# Altium schematic sheet Audio_Input_Mic_In.SchDoc
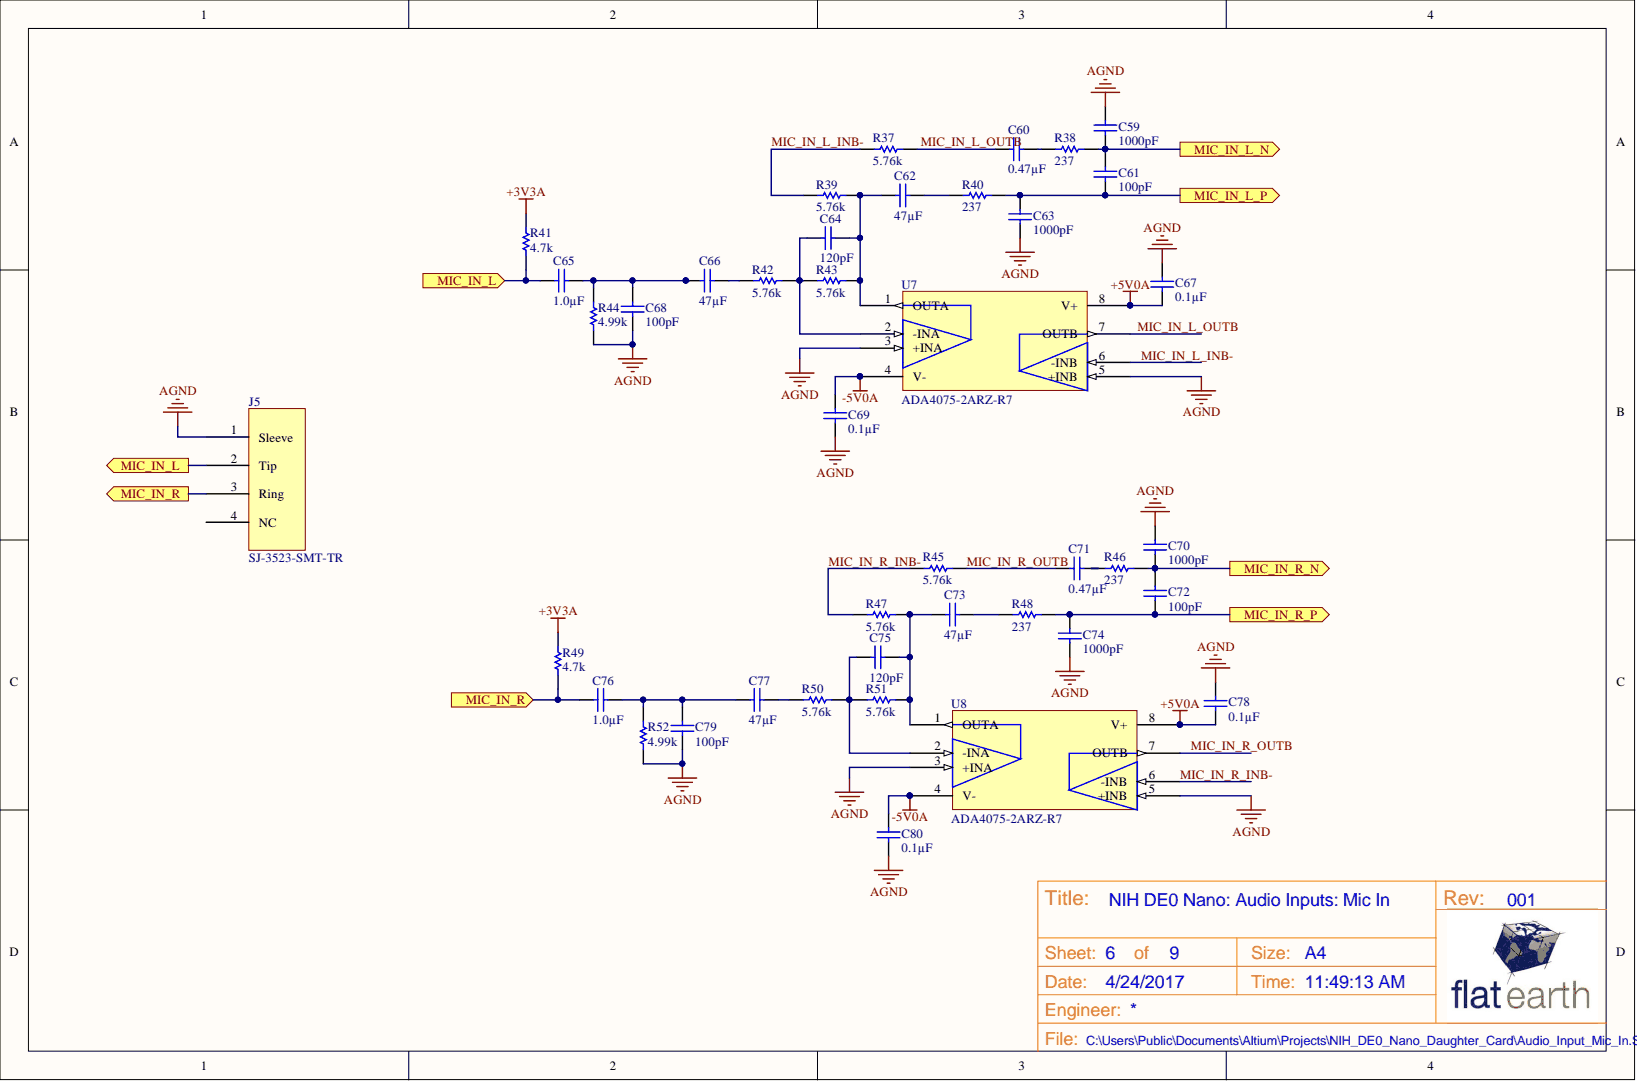
[diagram p0: Audio_Input_Mic_In.SchDoc]

## Parts
C59  Capacitance: 1000pF  [3-0603_L]  (hidden comment: CL10B102KB8NNNC)
C60  Capacitance: 0.47µF  [3-0603_L]  (hidden comment: C1608X7R1H474K080AC)
C61  Capacitance: 100pF  [3-0603_L]  (hidden comment: C1608C0G2A101J)
C62  Capacitance: 47µF  [C1210X25_L]  (hidden comment: GRM32EC81C476KE15L)
C63  Capacitance: 1000pF  [3-0603_L]  (hidden comment: CL10B102KB8NNNC)
C64  Capacitance: 120pF  [3-0603_L]  (hidden comment: CL10C121JB8NCNC)
C65  Capacitance: 1.0µF  [3-0603_L]  (hidden comment: C1608X7R1C105K)
C66  Capacitance: 47µF  [C1210X25_L]  (hidden comment: GRM32EC81C476KE15L)
C67  Capacitance: 0.1µF  [3-0603_L]  (hidden comment: C1608X7R1H104K)
C68  Capacitance: 100pF  [3-0603_L]  (hidden comment: C1608C0G2A101J)
C69  Capacitance: 0.1µF  [3-0603_L]  (hidden comment: C1608X7R1H104K)
C70  Capacitance: 1000pF  [3-0603_L]  (hidden comment: CL10B102KB8NNNC)
C71  Capacitance: 0.47µF  [3-0603_L]  (hidden comment: C1608X7R1H474K080AC)
C72  Capacitance: 100pF  [3-0603_L]  (hidden comment: C1608C0G2A101J)
C73  Capacitance: 47µF  [C1210X25_L]  (hidden comment: GRM32EC81C476KE15L)
C74  Capacitance: 1000pF  [3-0603_L]  (hidden comment: CL10B102KB8NNNC)
C75  Capacitance: 120pF  [3-0603_L]  (hidden comment: CL10C121JB8NCNC)
C76  Capacitance: 1.0µF  [3-0603_L]  (hidden comment: C1608X7R1C105K)
C77  Capacitance: 47µF  [C1210X25_L]  (hidden comment: GRM32EC81C476KE15L)
C78  Capacitance: 0.1µF  [3-0603_L]  (hidden comment: C1608X7R1H104K)
C79  Capacitance: 100pF  [3-0603_L]  (hidden comment: C1608C0G2A101J)
C80  Capacitance: 0.1µF  [3-0603_L]  (hidden comment: C1608X7R1H104K)
J5  SJ-3523-SMT-TR  [SJ-3523-SMT-TR]
R37  Resistance (Ohms): 5.76k  [3-0603_R_L]  (hidden comment: ERJ-3EKF5761V)
R38  ERJ-3EKF2370V  [3-0603_R_L]  (value not drawn)
R39  Resistance (Ohms): 5.76k  [3-0603_R_L]  (hidden comment: ERJ-3EKF5761V)
R40  ERJ-3EKF2370V  [3-0603_R_L]  (value not drawn)
R41  Resistance (Ohms): 4.7k  [3-0603_R_L]  (hidden comment: ERJ-3EKF4701V)
R42  Resistance (Ohms): 5.76k  [3-0603_R_L]  (hidden comment: ERJ-3EKF5761V)
R43  Resistance (Ohms): 5.76k  [3-0603_R_L]  (hidden comment: ERJ-3EKF5761V)
R44  Resistance (Ohms): 4.99k  [3-0603_R_L]  (hidden comment: ERJ-3EKF4991V)
R45  Resistance (Ohms): 5.76k  [3-0603_R_L]  (hidden comment: ERJ-3EKF5761V)
R46  ERJ-3EKF2370V  [3-0603_R_L]  (value not drawn)
R47  Resistance (Ohms): 5.76k  [3-0603_R_L]  (hidden comment: ERJ-3EKF5761V)
R48  ERJ-3EKF2370V  [3-0603_R_L]  (value not drawn)
R49  Resistance (Ohms): 4.7k  [3-0603_R_L]  (hidden comment: ERJ-3EKF4701V)
R50  Resistance (Ohms): 5.76k  [3-0603_R_L]  (hidden comment: ERJ-3EKF5761V)
R51  Resistance (Ohms): 5.76k  [3-0603_R_L]  (hidden comment: ERJ-3EKF5761V)
R52  Resistance (Ohms): 4.99k  [3-0603_R_L]  (hidden comment: ERJ-3EKF4991V)
U7  ADA4075-2ARZ-R7  [SOIC127P600X175-8L]
U8  ADA4075-2ARZ-R7  [SOIC127P600X175-8L]

## Ports
MIC_IN_L, MIC_IN_L_N, MIC_IN_L_P, MIC_IN_R, MIC_IN_R_N, MIC_IN_R_P

## Nets
+3V3A: R41.2 R49.2
+5V0A: C67.1 C78.1 U7.8(V+) U8.8(V+)
-5V0A: C69.1 C80.1 U7.4(V-) U8.4(V-)
AGND: C59.1 C63.1 C67.2 C68.2 C69.2 C70.1 C74.1 C78.2 C79.2 C80.2 J5.1(Sleeve) R44.1 R52.1 U7.3(+INA) U7.5(+INB) U8.3(+INA) U8.5(+INB)
MIC_IN_L: C65.1 J5.2(Tip) R41.1 ; port MIC_IN_L
MIC_IN_L_INB-: R37.1 R39.1 U7.6(-INB)
MIC_IN_L_N: C59.2 C61.2 R38.2 ; port MIC_IN_L_N
MIC_IN_L_OUTB: C60.1 R37.2 U7.7(OUTB)
MIC_IN_L_P: C61.1 C63.2 R40.2 ; port MIC_IN_L_P
MIC_IN_R: C76.1 J5.3(Ring) R49.1 ; port MIC_IN_R
MIC_IN_R_INB-: R45.1 R47.1 U8.6(-INB)
MIC_IN_R_N: C70.2 C72.2 R46.2 ; port MIC_IN_R_N
MIC_IN_R_OUTB: C71.1 R45.2 U8.7(OUTB)
MIC_IN_R_P: C72.1 C74.2 R48.2 ; port MIC_IN_R_P
NetC60_2: C60.2 R38.1
NetC62_1: C62.1 C64.2 R39.2 R43.2 U7.1(OUTA)
NetC62_2: C62.2 R40.1
NetC64_1: C64.1 R42.2 R43.1 U7.2(-INA)
NetC65_2: C65.2 C66.1 C68.1 R44.2
NetC66_2: C66.2 R42.1
NetC71_2: C71.2 R46.1
NetC73_1: C73.1 C75.2 R47.2 R51.2 U8.1(OUTA)
NetC73_2: C73.2 R48.1
NetC75_1: C75.1 R50.2 R51.1 U8.2(-INA)
NetC76_2: C76.2 C77.1 C79.1 R52.2
NetC77_2: C77.2 R50.1

Not connected: J5 4(NC)

## Library data of the parts
C59 C63 C70 C74 = CL10B102KB8NNNC: 1000pF 50V Ceramic Capacitor X7R 0603 (1608 Metric) 0.063" L x 0.031" W (1.60mm x 0.80mm); Manufacturer: Samsung Electro-Mechanics America, Inc.; Tolerance: ±10%; Applications: General Purpose; Category: Capacitors; Component Kind: Standard; ComponentLink1Description: http://www.samsungsem.com/kr/support/product-search/mlcc/__icsFiles/afieldfile/2015/06/15/CL10B102KB8NNNC.pdf; ComponentLink1URL: http://www.samsungsem.com/kr/support/product-search/mlcc/__icsFiles/afieldfile/2015/06/15/CL10B102KB8NNNC.pdf; Features: -; Height - Seated (Max): -; Lead Spacing: -; Lead Style: -; Library Name: Schematic_Components.SchLib; Manufacturer Part Number: CL10B102KB8NNNC; Mounting Type: Surface Mount, MLCC; Operating Temperature: -55°C ~ 125°C; Package / Case: 0603 (1608 Metric); Packaging: Cut Tape (CT); Part Status: Active; Pricing 1: 1=0.1, 10=0.017, 25=0.012, 50=0.0092, 100=0.0077, 500=0.0054, 1000=0.0042 (USD); Ratings: -; RoHS: RoHS Compliant; Size / Dimension: 0.063" L x 0.031" W (1.60mm x 0.80mm); Stock 1: 294007; Supplier 1: Digi-Key; Supplier Part Number 1: 1276-1018-1-ND; Temperature Coefficient: X7R; Thickness (Max): 0.035" (0.90mm); Voltage - Rated: 50V
C60 C71 = C1608X7R1H474K080AC: CAP CER 0.47UF 50V 10% X7R 0603; Manufacturer: TDK Corporation; Applications: General Purpose; Category: Capacitors; Component Kind: Standard; ComponentLink1Description: http://product.tdk.com/capacitor/mlcc/en/documents/mlcc_commercial_general_en.pdf; ComponentLink1URL: http://product.tdk.com/capacitor/mlcc/en/documents/mlcc_commercial_general_en.pdf; Features: -; Height - Seated (Max): -; Lead Spacing: -; Lead Style: -; Library Name: Schematic_Components.SchLib; Manufacturer Part Number: C1608X7R1H474K080AC; Mounting Type: Surface Mount, MLCC; Operating Temperature: -55°C ~ 125°C; Package / Case: 0603 (1608 Metric); Packaging: Cut Tape (CT); Pricing 1: 1=0.24, 10=0.2, 100=0.1, 250=0.075, 500=0.06626, 1000=0.05875 (USD); Ratings: -; RoHS: RoHS Compliant; Size / Dimension: 0.063" L x 0.031" W (1.60mm x 0.80mm); Stock 1: 0; Supplier 1: Digi-Key; Supplier Part Number 1: 445-5952-1-ND; Temperature Coefficient: X7R; Thickness (Max): 0.037" (0.95mm); Tolerance: ±10%; Voltage - Rated: 50V
C61 C68 C72 C79 = C1608C0G2A101J: CAP CER 100PF 100V 5% NP0 0603; Manufacturer: TDK Corporation; Temperature Coefficient: C0G, NP0; Applications: General Purpose; Category: Capacitors; Component Kind: Standard; ComponentLink1Description: http://www.tdk.com/pdf/mid_volt_B11.pdf; ComponentLink1URL: http://www.tdk.com/pdf/mid_volt_B11.pdf; Features: -; Height - Seated (Max): -; Lead Spacing: -; Lead Style: -; Library Name: Schematic_Components.SchLib; Manufacturer Part Number: C1608C0G2A101J; Mounting Type: Surface Mount, MLCC; Operating Temperature: -55°C ~ 125°C; Package / Case: 0603 (1608 Metric); Packaging: Cut Tape (CT); Pricing 1: 10=0.05, 100=0.0231, 250=0.01892, 500=0.01618, 1000=0.0126 (USD); Ratings: -; RoHS: RoHS Compliant; Size / Dimension: 0.063" L x 0.031" W (1.60mm x 0.80mm); Stock 1: 128588; Supplier 1: Digi-Key; Supplier Part Number 1: 445-2306-1-ND; Thickness (Max): 0.037" (0.95mm); Tolerance: ±5%; Voltage - Rated: 100V
C62 C66 C73 C77 = GRM32EC81C476KE15L: 47µF ±10% 16V Ceramic Capacitor X6S 1210 (3225 Metric); Manufacturer: Murata Electronics North America; Applications: General Purpose; Category: Capacitors; Component Kind: Standard; ComponentLink1Description: http://www.murata.com/~/media/webrenewal/support/library/catalog/products/capacitor/mlcc/c02e.pdf; ComponentLink1URL: http://www.murata.com/~/media/webrenewal/support/library/catalog/products/capacitor/mlcc/c02e.pdf; Features: Derating Recommended; Height - Seated (Max): -; Lead Spacing: -; Lead Style: -; Library Name: Schematic_Components.SchLib; Manufacturer Part Number: GRM32EC81C476KE15L; Mounting Type: Surface Mount, MLCC; Operating Temperature: -55°C ~ 105°C; Package / Case: 1210 (3225 Metric); Packaging: Cut Tape (CT); Part Status: Active; Pricing 1: 1=0.73, 10=0.697, 25=0.4944, 50=0.4164, 100=0.3383, 250=0.28108, 500=0.25504 (USD); Ratings: -; RoHS: RoHS Compliant; Size / Dimension: 0.126" L x 0.098" W (3.20mm x 2.50mm); Stock 1: 39641; Supplier 1: Digi-Key; Supplier Part Number 1: 490-10531-1-ND; Temperature Coefficient: X6S; Thickness (Max): 0.106" (2.70mm); Tolerance: ±10%; Voltage - Rated: 16V
C64 C75 = CL10C121JB8NCNC: 120pF 50V Ceramic Capacitor C0G, NP0 0603 (1608 Metric) 0.063" L x 0.031" W (1.60mm x 0.80mm); Manufacturer: Samsung Electro-Mechanics America, Inc.; Tolerance: ±5%; Applications: General Purpose; Category: Capacitors; Component Kind: Standard; ComponentLink1Description: http://media.digikey.com/pdf/Data%20Sheets/Samsung%20PDFs/CL10C121JB8NCNC_Spec.pdf; ComponentLink1URL: http://media.digikey.com/pdf/Data%20Sheets/Samsung%20PDFs/CL10C121JB8NCNC_Spec.pdf; Features: -; Height - Seated (Max): -; Lead Spacing: -; Lead Style: -; Library Name: Schematic_Components.SchLib; Manufacturer Part Number: CL10C121JB8NCNC; Mounting Type: Surface Mount, MLCC; Operating Temperature: -55°C ~ 125°C; Package / Case: 0603 (1608 Metric); Packaging: Cut Tape (CT); Part Status: Active; Pricing 1: 1=0.1, 10=0.03, 25=0.0208, 50=0.016, 100=0.0135, 500=0.00948, 1000=0.00738 (USD); Ratings: -; RoHS: RoHS Compliant; Size / Dimension: 0.063" L x 0.031" W (1.60mm x 0.80mm); Stock 1: 26285; Supplier 1: Digi-Key; Supplier Part Number 1: 1276-2180-1-ND; Temperature Coefficient: C0G, NP0; Thickness (Max): 0.035" (0.90mm); Voltage - Rated: 50V
C65 C76 = C1608X7R1C105K: CAP CER 1UF 16V 10% X7R 0603; Manufacturer: TDK Corporation; Applications: General Purpose; Category: Capacitors; Component Kind: Standard; ComponentLink1Description: http://www.tdk.com/pdf/general_B11.pdf; ComponentLink1URL: http://www.tdk.com/pdf/general_B11.pdf; Features: -; Height - Seated (Max): -; Lead Spacing: -; Lead Style: -; Library Name: Schematic_Components.SchLib; Manufacturer Part Number: C1608X7R1C105K; Mounting Type: Surface Mount, MLCC; Operating Temperature: -55°C ~ 125°C; Package / Case: 0603 (1608 Metric); Packaging: Cut Tape (CT); Pricing 1: 10=0.077, 100=0.0366, 250=0.0308, 500=0.0258, 1000=0.02118 (USD); Ratings: -; RoHS: RoHS Compliant; Size / Dimension: 0.063" L x 0.031" W (1.60mm x 0.80mm); Stock 1: 1908993; Supplier 1: Digi-Key; Supplier Part Number 1: 445-1604-1-ND; Temperature Coefficient: X7R; Thickness (Max): 0.037" (0.95mm); Tolerance: ±10%; Voltage - Rated: 16V
C67 C69 C78 C80 = C1608X7R1H104K: CAP CER 0.1UF 50V 10% X7R 0603; Manufacturer: TDK Corporation; Applications: General Purpose; Category: Capacitors; Component Kind: Standard; ComponentLink1Description: http://www.tdk.com/pdf/general_B11.pdf; ComponentLink1URL: http://www.tdk.com/pdf/general_B11.pdf; Features: -; Height - Seated (Max): -; Lead Spacing: -; Lead Style: -; Library Name: Schematic_Components.SchLib; Manufacturer Part Number: C1608X7R1H104K; Mounting Type: Surface Mount, MLCC; Operating Temperature: -55°C ~ 125°C; Package / Case: 0603 (1608 Metric); Packaging: Cut Tape (CT); Pricing 1: 10=0.048, 100=0.022, 250=0.018, 500=0.0154, 1000=0.012 (USD); Ratings: -; RoHS: RoHS Compliant; Size / Dimension: 0.063" L x 0.031" W (1.60mm x 0.80mm); Stock 1: 2442296; Supplier 1: Digi-Key; Supplier Part Number 1: 445-1314-1-ND; Temperature Coefficient: X7R; Thickness (Max): 0.037" (0.95mm); Tolerance: ±10%; Voltage - Rated: 50V
J5 = SJ-3523-SMT-TR: 3.50mm (0.141", 1/8", Mini Plug) - Headphone Phone Jack Stereo Connector Solder; Manufacturer: CUI Inc.; Actual Diameter: 0.142" (3.60mm); Body Color: Black; Current Rating: 1A; Gender: Female; Includes: 1 pc - 1 Connector; Internal Switch(s): Does Not Contain Switch; Mating Length/Depth: 0.551" (14.00mm); Number of Positions/Contacts: 3 Conductors, 3 Contacts; Shielding: Unshielded; Voltage - Rated: 12VDC; Body Material: -; Category: Connectors, Interconnects; Component Kind: Standard; ComponentLink1Description: http://www.cui.com/product/resource/digikeypdf/sj-352x-smt_series.pdf; ComponentLink1URL: http://www.cui.com/product/resource/digikeypdf/sj-352x-smt_series.pdf; Connector Type: Phone Jack; Contact Material - Plating: Silver; Features: Board Guide; Industry Recognized Mating Diameter: 3.50mm (0.141", 1/8", Mini Plug) - Headphone; Insulation Color: -; LatestRevisionDate: 17-Jul-2002; LatestRevisionNote: Re-released for DXP Platform.; Manufacturer Part Number: SJ-3523-SMT-TR; Mounting Type: Surface Mount, Right Angle; Packaging: Cut Tape (CT); Part Status: Active; Pricing 1: 1=1.34, 10=1.043, 100=0.7961, 500=0.63558 (USD); RoHS: RoHS Compliant; Signal Lines: Stereo; Stock 1: 104981; Supplier 1: Digi-Key; Supplier Part Number 1: CP-3523SJCT-ND; Termination: Solder; Library Name: NIH_DE0_Nano_Daughter_Card_Library.SchLib; Publisher: Altium Limited
R37 R39 R42 R43 R45 R47 R50 R51 = ERJ-3EKF5761V: RES SMD 5.76K OHM 1% 1/10W 0603; Manufacturer: Panasonic Electronic Components; Power (Watts): 0.1W, 1/10W; Temperature Coefficient: ±100ppm/°C; Category: Resistors; Component Kind: Standard; ComponentLink1Description: http://industrial.panasonic.com/www-cgi/jvcr13pz.cgi?E+PZ+3+AOA0002+ERJ3EKF5761V+7+WW; ComponentLink1URL: http://industrial.panasonic.com/www-cgi/jvcr13pz.cgi?E+PZ+3+AOA0002+ERJ3EKF5761V+7+WW; Composition: Thick Film; Features: Automotive AEC-Q200; Height: 0.022" (0.55mm); Library Name: Schematic_Components.SchLib; Manufacturer Part Number: ERJ-3EKF5761V; Number of Terminations: 2; Operating Temperature: -55°C ~ 155°C; Package / Case: 0603 (1608 Metric); Packaging: Cut Tape (CT); Part Status: Active; Pricing 1: 1=0.1, 50=0.0114, 100=0.0084, 250=0.00644, 500=0.00514, 1000=0.00379 (USD); RoHS: RoHS Compliant; Size / Dimension: 0.063" L x 0.031" W (1.60mm x 0.80mm); Stock 1: 90842; Supplier 1: Digi-Key; Supplier Device Package: 0603; Supplier Part Number 1: P5.76KHCT-ND; Tolerance: ±1%
R38 R40 R46 R48 = ERJ-3EKF2370V: RES SMD 237 OHM 1% 1/10W 0603; Manufacturer: Panasonic Electronic Components; Power (Watts): 0.1W, 1/10W; Resistance (Ohms): 237; Temperature Coefficient: ±100ppm/°C; Category: Resistors; Component Kind: Standard; ComponentLink1Description: http://industrial.panasonic.com/www-cgi/jvcr13pz.cgi?E+PZ+3+AOA0002+ERJ3EKF2370V+7+WW; ComponentLink1URL: http://industrial.panasonic.com/www-cgi/jvcr13pz.cgi?E+PZ+3+AOA0002+ERJ3EKF2370V+7+WW; Composition: Thick Film; Features: Automotive AEC-Q200; Height: 0.022" (0.55mm); Library Name: Schematic_Components.SchLib; Manufacturer Part Number: ERJ-3EKF2370V; Number of Terminations: 2; Operating Temperature: -55°C ~ 155°C; Package / Case: 0603 (1608 Metric); Packaging: Cut Tape (CT); Part Status: Active; Pricing 1: 1=0.1, 50=0.0114, 100=0.0084, 250=0.00644, 500=0.00514, 1000=0.00379 (USD); RoHS: RoHS Compliant; Size / Dimension: 0.063" L x 0.031" W (1.60mm x 0.80mm); Stock 1: 74413; Supplier 1: Digi-Key; Supplier Device Package: 0603; Supplier Part Number 1: P237HCT-ND; Tolerance: ±1%
R41 R49 = ERJ-3EKF4701V: RES SMD 4.7K OHM 1% 1/10W 0603; Manufacturer: Panasonic Electronic Components; Power (Watts): 0.1W, 1/10W; Temperature Coefficient: ±100ppm/°C; Category: Resistors; Component Kind: Standard; ComponentLink1Description: http://industrial.panasonic.com/www-cgi/jvcr13pz.cgi?E+PZ+3+AOA0002+ERJ3EKF4701V+7+WW; ComponentLink1URL: http://industrial.panasonic.com/www-cgi/jvcr13pz.cgi?E+PZ+3+AOA0002+ERJ3EKF4701V+7+WW; Composition: Thick Film; Features: Automotive AEC-Q200; Height: 0.022" (0.55mm); Library Name: Schematic_Components.SchLib; Manufacturer Part Number: ERJ-3EKF4701V; Number of Terminations: 2; Operating Temperature: -55°C ~ 155°C; Package / Case: 0603 (1608 Metric); Packaging: Cut Tape (CT); Part Status: Active; Pricing 1: 1=0.1, 50=0.0114, 100=0.0084, 250=0.00644, 500=0.00514, 1000=0.00379 (USD); RoHS: RoHS Compliant; Size / Dimension: 0.063" L x 0.031" W (1.60mm x 0.80mm); Stock 1: 1874817; Supplier 1: Digi-Key; Supplier Device Package: 0603; Supplier Part Number 1: P4.70KHCT-ND; Tolerance: ±1%
R44 R52 = ERJ-3EKF4991V: RES SMD 4.99K OHM 1% 1/10W 0603; Manufacturer: Panasonic Electronic Components; Power (Watts): 0.1W, 1/10W; Temperature Coefficient: ±100ppm/°C; Category: Resistors; Component Kind: Standard; ComponentLink1Description: http://industrial.panasonic.com/www-cgi/jvcr13pz.cgi?E+PZ+3+AOA0002+ERJ3EKF4991V+7+WW; ComponentLink1URL: http://industrial.panasonic.com/www-cgi/jvcr13pz.cgi?E+PZ+3+AOA0002+ERJ3EKF4991V+7+WW; Composition: Thick Film; Features: Automotive AEC-Q200; Height: 0.022" (0.55mm); Library Name: Schematic_Components.SchLib; Manufacturer Part Number: ERJ-3EKF4991V; Number of Terminations: 2; Operating Temperature: -55°C ~ 155°C; Package / Case: 0603 (1608 Metric); Packaging: Cut Tape (CT); Part Status: Active; Pricing 1: 1=0.1, 50=0.0114, 100=0.0084, 250=0.00644, 500=0.00514, 1000=0.00379 (USD); RoHS: RoHS Compliant; Size / Dimension: 0.063" L x 0.031" W (1.60mm x 0.80mm); Stock 1: 870970; Supplier 1: Digi-Key; Supplier Device Package: 0603; Supplier Part Number 1: P4.99KHCT-ND; Tolerance: ±1%
U7 U8 = ADA4075-2ARZ-R7: IC OPAMP GP 6.5MHZ 8SOIC; Manufacturer: Analog Devices Inc.; Amplifier Type: General Purpose; Current - Input Bias: 30nA; Current - Output / Channel: 40mA; Current - Supply: 1.8mA; Number of Circuits: 2; Slew Rate: 12 V/µs; Voltage - Input Offset: 200µV; Voltage - Supply, Single/Dual (±): ±4.5 V ~ 18 V; -3db Bandwidth: -; Category: Integrated Circuits (ICs); Component Kind: Standard; ComponentLink1Description: http://www.analog.com/media/en/technical-documentation/data-sheets/ADA4075-2.pdf; ComponentLink1URL: http://www.analog.com/media/en/technical-documentation/data-sheets/ADA4075-2.pdf; Gain Bandwidth Product: 6.5MHz; Manufacturer Part Number: ADA4075-2ARZ-R7; Mounting Type: Surface Mount; Operating Temperature: -40°C ~ 125°C; Output Type: -; Package / Case: 8-SOIC (0.154", 3.90mm Width); Packaging: Cut Tape (CT); Part Status: Active; Pricing 1: 1=2.28, 10=2.05, 100=1.6441, 500=1.35136 (USD); RoHS: RoHS Compliant; Stock 1: 11196; Supplier 1: Digi-Key; Supplier Device Package: 8-SOIC; Supplier Part Number 1: ADA4075-2ARZ-R7CT-ND; Library Name: NIH_DE0_Nano_Daughter_Card_Library.SchLib
